# Altium schematic sheet 11_AP-DC_C.SchDoc(11_AP-DC_C)
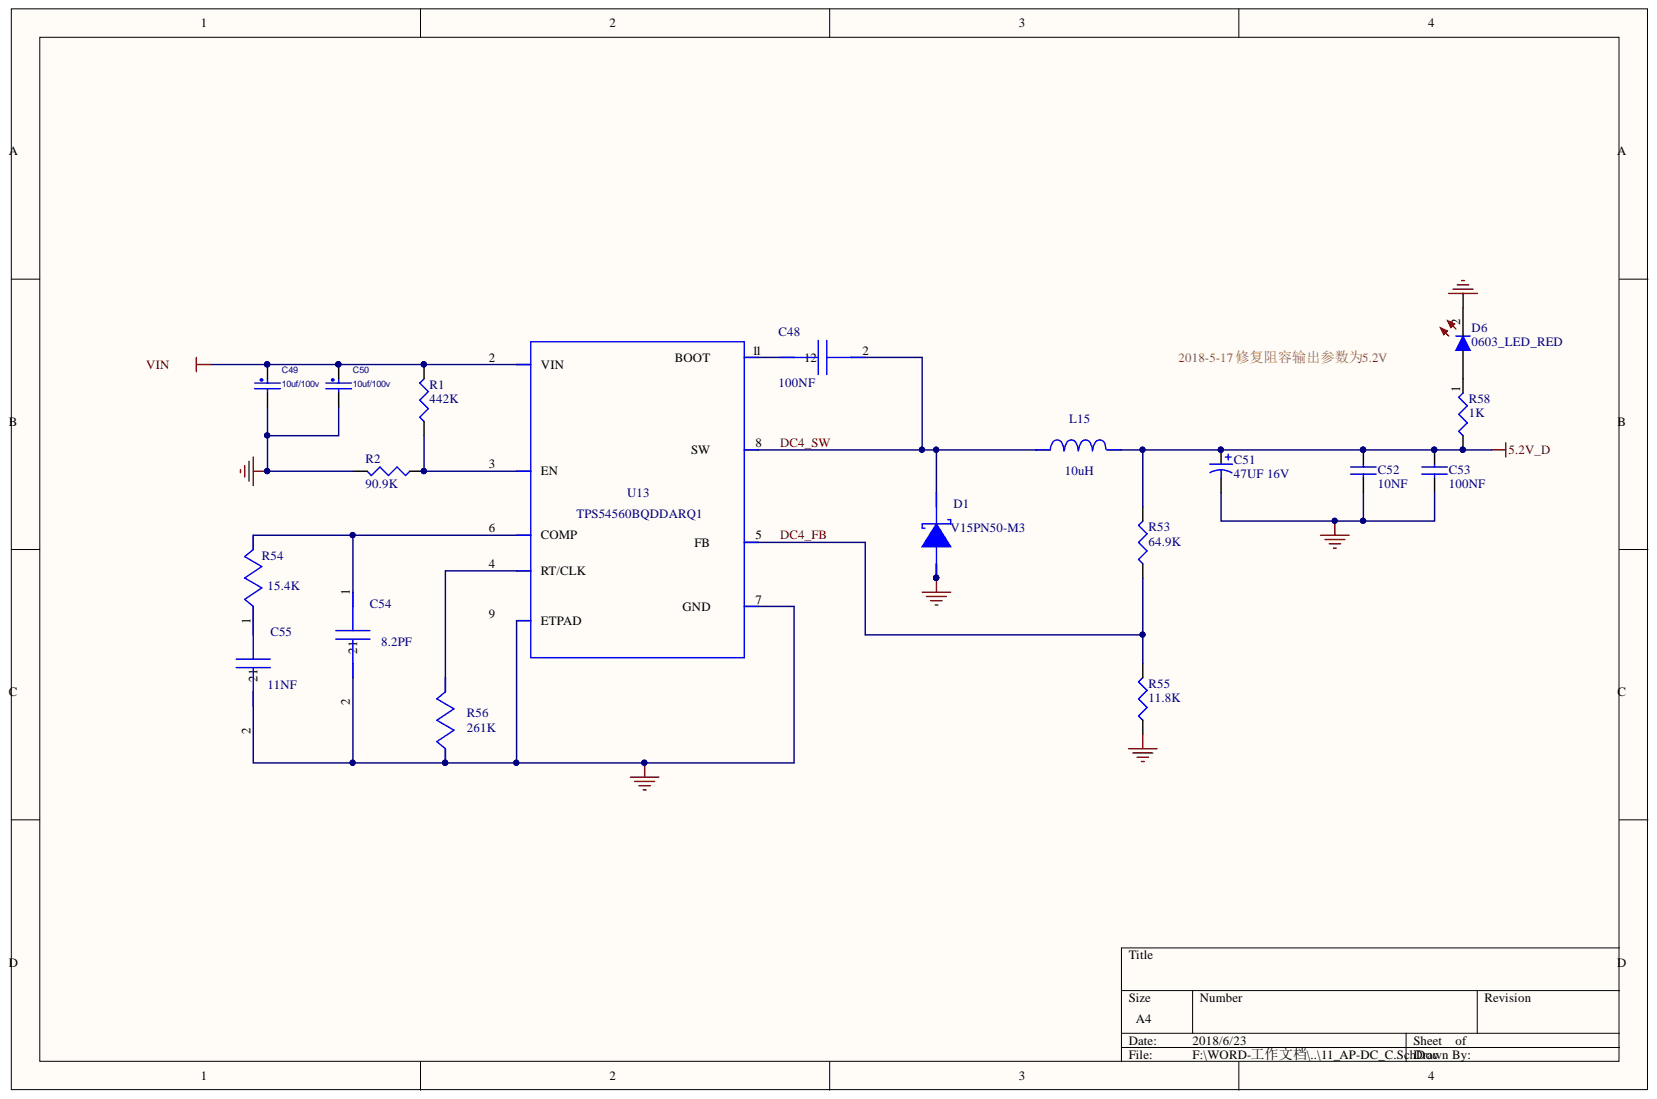
[diagram p0: 11_AP-DC_C.SchDoc]

## Parts
C48  100NF  [0402c]
C49  10uf/100v  [C2220]
C50  10uf/100v  [C2220]
C51  47UF 16V  [7343CAP]
C52  10NF  [0402C]
C53  100NF  [0402C]
C54  8.2PF  [0402c]
C55  11NF  [0402c]
D1  V15PN50-M3  [TO-277]
D6  0603_LED_RED  [0603-LED-RED]
L15  value 10uH  [10X10L]  (hidden comment: PCMB104T-100MS)
R1  442K  [R0402]
R2  90.9K  [R0402]
R53  64.9K  [R0402]
R54  15.4K  [0402R]
R55  11.8K  [R0402]
R56  261K  [0402R]
R58  1K  [R0402]
U13  TPS54560BQDDAR  [SO_P_8]

## Nets
5.2V_D: C51.A C52.1 C53.1 L15.2 R53.2 R58.1
GND: C49.2 C50.2 C51.C C52.2 C53.2 C54.2 C55.2 D1.A D6.2 R2.2 R55.1 R56.2 U13.7 U13.9(ETPAD)
VIN: C49.1 C50.1 R1.2 U13.2(VIN)
DC4_FB: R53.1 R55.2 U13.5
DC4_SW: C48.2 D1.K L15.1 U13.8
NetC48_1: C48.1 U13.1
NetC54_1: C54.1 R54.1 U13.6(COMP)
NetC55_1: C55.1 R54.2
NetD6_1: D6.1 R58.2
NetR1_1: R1.1 R2.1 U13.3(EN)
NetR56_1: R56.1 U13.4(RT/CLK)
2018-5-17 修复阻容输出参数为5.2V:

## Library data of the parts
C48 C54 = GRM1555C1H9R1CA01D: GRM1555C1H9R1CA0; Manufacturer Name: MuRata; Component Kind: Standard; Library Name: TI WEBENCH; Manufacturer Part Number: GRM1555C1H9R1CA01D; PackageReference: GRM1555C1H9R1CA01D
  C48: Value: 100NF
C49 C50 = CAP_NP: Component Kind: Standard
C51 = Cap Pol1: T520V476M016ATE; Component Kind: Standard; LatestRevisionDate: 17-Jul-2002; LatestRevisionNote: Re-released for DXP Platform.; Library Name: Miscellaneous Devices.IntLib; PackageDescription: Polarized Capacitor (Radial); 2 Leads; PackageReference: RB7.6-15; Published: 8-Jun-2000; Signal Integrity: Cap Pol; Simulation: CAP; Publisher: Altium Limited
C52 C53 = C1005X5R1H104K: Component Kind: Standard; Library Name: Integrated_Library_RF_FEB_2012.IntLib
C55 = GRM3195C1H113JA01D: GRM3195C1H113JA0; Manufacturer Name: MuRata; Component Kind: Standard; Library Name: TI WEBENCH; Manufacturer Part Number: GRM3195C1H113JA01D; PackageReference: GRM3195C1H113JA01D
D1 = STPS20M100SG-TR: Manufacturer Name: STMicroelectronics; Component Kind: Standard; Library Name: TI WEBENCH; Manufacturer Part Number: STPS20M100SG-TR; PackageReference: STPS20M100SG-TR
L15 = SRP1270-100M: Manufacturer Name: Bourns; Component Kind: Standard; Description: SRP1270-100M; Library Name: TI WEBENCH; Manufacturer Part Number: SRP1270-100M; PackageReference: SRP1270-100M
R1 R2 R53 R55 R58 = RC0402FR-0749R9L: Component Kind: Standard; Library Name: Integrated_Library_RF_FEB_2012.IntLib
R54 = CRCW0402274KFKED: Component Kind: Standard; Library Name: TI WEBENCH
R56 = CRCW0402274KFKED: Manufacturer Name: Vishay-Dale; Component Kind: Standard; Description: CRCW0402274KFKED; Library Name: TI WEBENCH; Manufacturer Part Number: CRCW0402274KFKED; PackageReference: CRCW0402274KFKED
U13 = TPS54560BQDDARQ1: Manufacturer Name: Texas Instruments; Component Kind: Standard; Description: TPS54560BQDDARQ1; Library Name: TI WEBENCH; Manufacturer Part Number: TPS54560BQDDARQ1; PackageReference: TPS54560BQDDARQ1; Value: Value
D6 = 0603_LED_RED: Component Kind: Standard; Description: 0603_LED_RED; Library Name: CUAV.SchLib
